# Altium schematic sheet CORE1.SchDoc(CORE1)
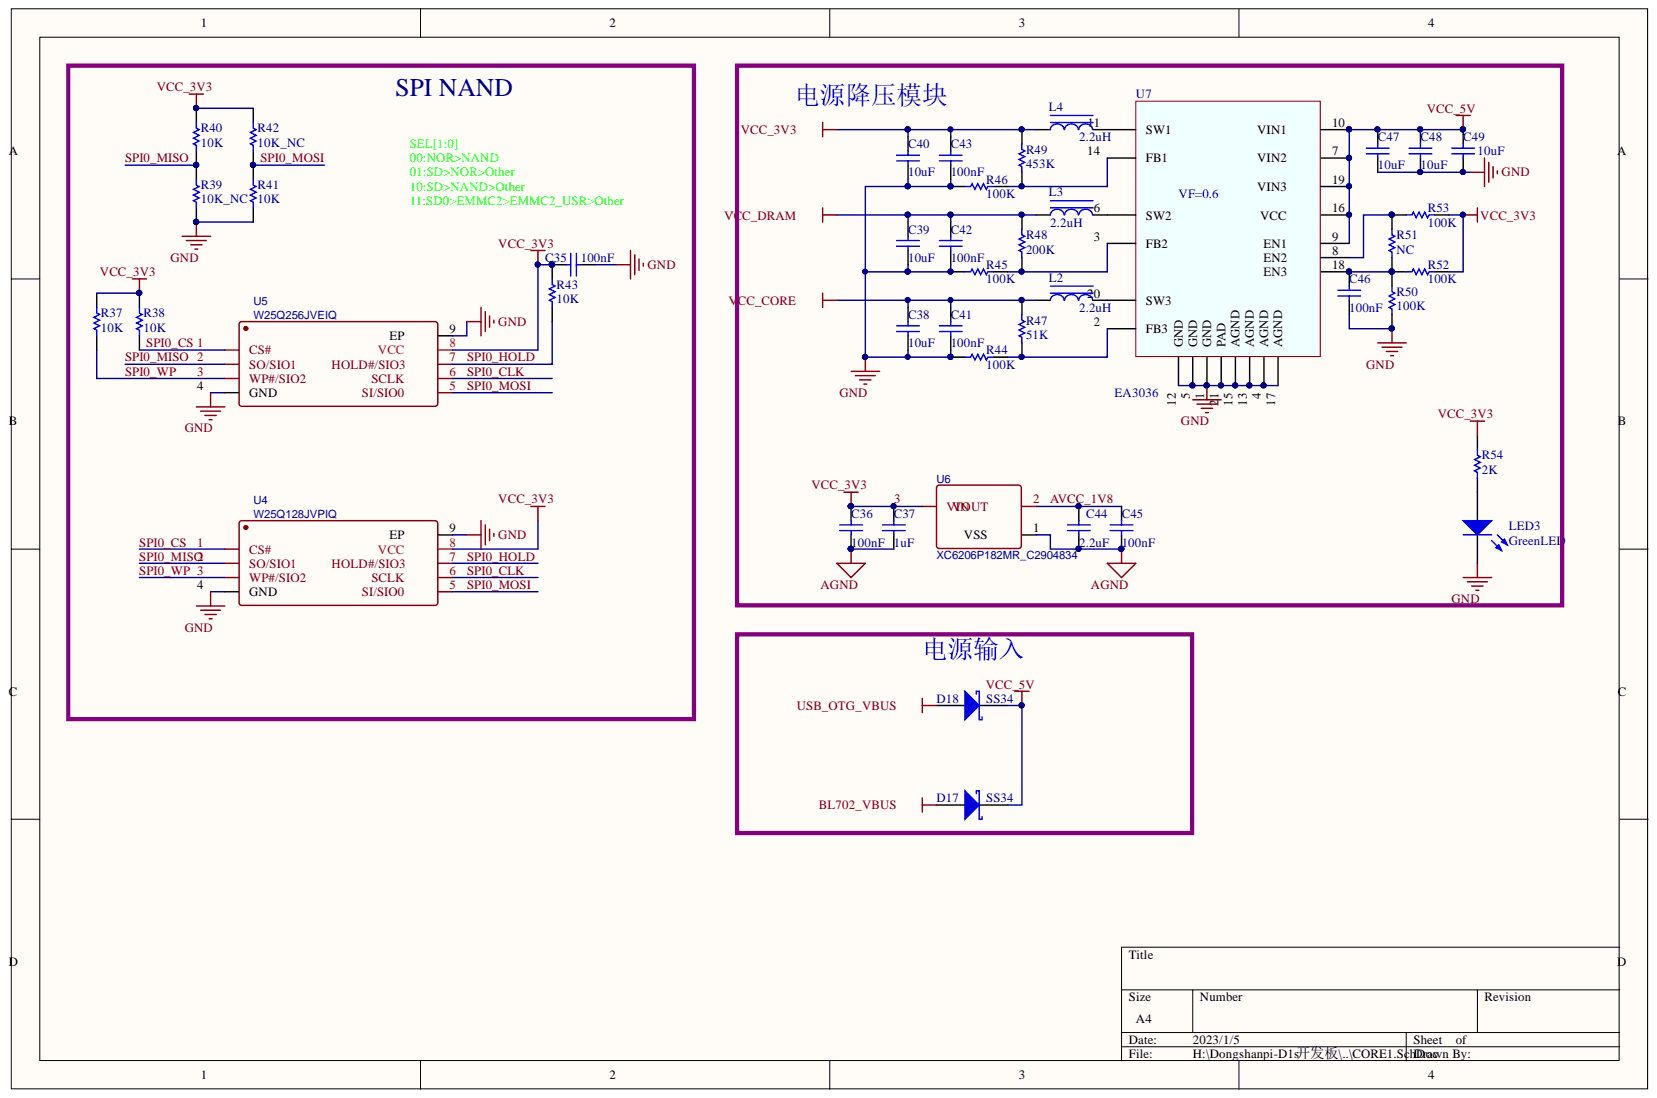
[diagram p0: CORE1.SchDoc]

## Parts
C35  100nF  [0402]
C36  100nF  [0402]
C37  1uF  [0402]
C38  10uF  [0402]
C39  10uF  [0402]
C40  10uF  [0402]
C41  100nF  [0402]
C42  100nF  [0402]
C43  100nF  [0402]
C44  2.2uF  [0402]
C45  100nF  [0402]
C46  100nF  [0402]
C47  10uF  [0402]
C48  10uF  [0402]
C49  10uF  [0402]
D17  SS34  [SMA]
D18  SS34  [SMA]
L2  2.2uH  [VLS2016]
L3  2.2uH  [VLS2016]
L4  2.2uH  [VLS2016]
LED3  GreenLED  [LED0402]
R37  10K  [0402]
R38  10K  [0402]
R39  10K_NC  [0402]
R40  10K  [0402]
R41  10K  [0402]
R42  10K_NC  [0402]
R43  10K  [0402]
R44  100K  [0402]
R45  100K  [0402]
R46  100K  [0402]
R47  51K  [0402]
R48  200K  [0402]
R49  453K  [0402]
R50  100K  [0402]
R51  NC  [0402]
R52  100K  [0402]
R53  100K  [0402]
R54  2K  [0402]
U4  W25Q128JVPIQ  [WSON-8_L5.0-W6.0-P1.27-BL-EP]
U5  W25Q256JVEIQ  [WSON-8_L6.0-W8.0-P1.27-BL-EP]
U6  XC6206P182MR_C2904834  [SOT23]
U7  EA3036  [QFN40P300X300X80_HS-21N]

## Nets
AGND: C36.2 C37.2 C44.2 C45.2 U6.1
BL702_VBUS: D17.1
GND: C35.2 C38.2 C39.2 C40.2 C41.1 C42.1 C43.1 C46.2 C47.2 C48.2 C49.2 LED3.2 R39.2 R41.2 R44.1 R45.1 R46.1 R50.1 U4.4(GND) U4.9 U5.4(GND) U5.9 U7.1(GND) U7.4(AGND) U7.5(GND) U7.12(GND) U7.13(AGND) U7.15(AGND) U7.17(AGND) U7.21(PAD)
USB_OTG_VBUS: D18.1
VCC_3V3: C35.1 C36.1 C37.1 C40.1 C43.2 L4.2 R37.1 R38.1 R40.1 R42.1 R43.1 R49.1 R52.2 R53.2 R54.2 U4.8 U5.8 U6.3
VCC_5V: C47.1 C48.1 C49.1 D17.2 D18.2 U7.7 U7.9 U7.10 U7.16 U7.19
VCC_CORE: C38.1 C41.2 L2.2 R47.1
VCC_DRAM: C39.1 C42.2 L3.2 R48.1
AVCC_1V8: C44.1 C45.1 U6.2
SPI0_CLK: U4.6 U5.6
SPI0_CS: R38.2 U4.1(CS#) U5.1(CS#)
SPI0_HOLD: R43.2 U4.7 U5.7
SPI0_MISO: R39.1 R40.2 U4.2(SO/SIO1) U5.2(SO/SIO1)
SPI0_MOSI: R41.1 R42.2 U4.5 U5.5
SPI0_WP: R37.2 U4.3(WP#/SIO2) U5.3(WP#/SIO2)
NetC46_1: C46.1 R50.2 R51.1 R52.1 U7.18
NetL2_1: L2.1 U7.20(SW3)
NetL3_1: L3.1 U7.6(SW2)
NetL4_1: L4.1 U7.11(SW1)
NetLED3_1: LED3.1 R54.1
NetR44_2: R44.2 R47.2 U7.2(FB3)
NetR45_2: R45.2 R48.2 U7.3(FB2)
NetR46_2: R46.2 R49.2 U7.14(FB1)
NetR51_2: R51.2 R53.1 U7.8

## Library data of the parts
C35-C49 = Cap: Capacitor; PartNumber: CAP100NF; Component Kind: Standard; LatestRevisionDate: 17-Jul-2002; LatestRevisionNote: Re-released for DXP Platform.; Library Name: Miscellaneous Devices.IntLib; PackageReference: 0402; Published: 8-Jun-2000; Signal Integrity: Cap; Simulation: CAP; Publisher: Altium Limited
  C35 C36 C41-C43 C45 C46: Value: 100nF
  C37: Value: 1uF
  C38-C40 C47-C49: Value: 10uF
  C44: Value: 2.2uF
D17 D18 = D Schottky: Schottky Diode; Code_JEDEC: DO-214-AA; Component Kind: Standard; LatestRevisionDate: 17-Jul-2002; LatestRevisionNote: Re-released for DXP Platform.; Library Name: Miscellaneous Devices.IntLib; PackageDescription: DO-214-AA/SMB; 2 C-Bend Leads; Body 5.3 x 3.6 mm, inc. leads (LxW); PackageReference: SMB; Published: 8-Jun-2000; Signal Integrity: D Schottky; Simulation: SKYDIODE; Value: SS34; Publisher: Altium Limited
L2-L4 = L: 普通电感; Component Kind: Standard
  L2 L4: Library Name: LIB_MANGO.IntLib
  L3: Library Name: A.SchLib
LED3 = LED2: Typical RED, GREEN, YELLOW, AMBER GaAs LED; Component Kind: Standard; LatestRevisionDate: 17-Jul-2002; LatestRevisionNote: Re-released for DXP Platform.; Library Name: Miscellaneous Devices.IntLib; PackageDescription: SM LED; 2 Flat Leads; PackageReference: 3.2X1.6X1.1; PackageVersion: Feb-2002; Published: 8-Jun-2000; Simulation: LED2; Value: GreenLED; Publisher: Altium Limited
R37-R54 = Res1: Resistor; PartNumber: RES2.2K; Component Kind: Standard; LatestRevisionDate: 17-Jul-2002; LatestRevisionNote: Re-released for DXP Platform.; Library Name: Miscellaneous Devices.IntLib; PackageReference: 0402; Published: 8-Jun-2000; Signal Integrity: Res; Simulation: RESISTOR; Publisher: Altium Limited
  R37 R38 R40 R41 R43: Value: 10K
  R39 R42: Value: 10K_NC
  R44-R46 R50 R52 R53: Value: 100K
  R47: Value: 51K
  R48: Value: 200K
  R49: Value: 453K
  R51: Value: NC
  R54: Value: 2K
U4 U5 = MX35LF1GE4AB-Z4I_C402160: Contributor: LCSC; BOM_JLCPCB Part Class: Extended Part; BOM_Supplier: LCSC; Component Kind: Standard; spicePre: U
  U4: BOM_Supplier Part: C190862
  U5: BOM_Supplier Part: C2682312
U6 = XC6206P182MR_C2904834: Contributor: LCSC; BOM_Manufacturer Part: XC6206P182MR; BOM_Supplier: LCSC; BOM_Supplier Part: C2904834; Component Kind: Standard; Library Name: HM2.SchLib; spicePre: U; spiceSymbolName: XC6206P182MR_C2904834
U7 = EA3036: Component Kind: Standard; Library Name: LIB_MANGO.IntLib
